# Altium schematic sheet 03 ADA4350.SchDoc
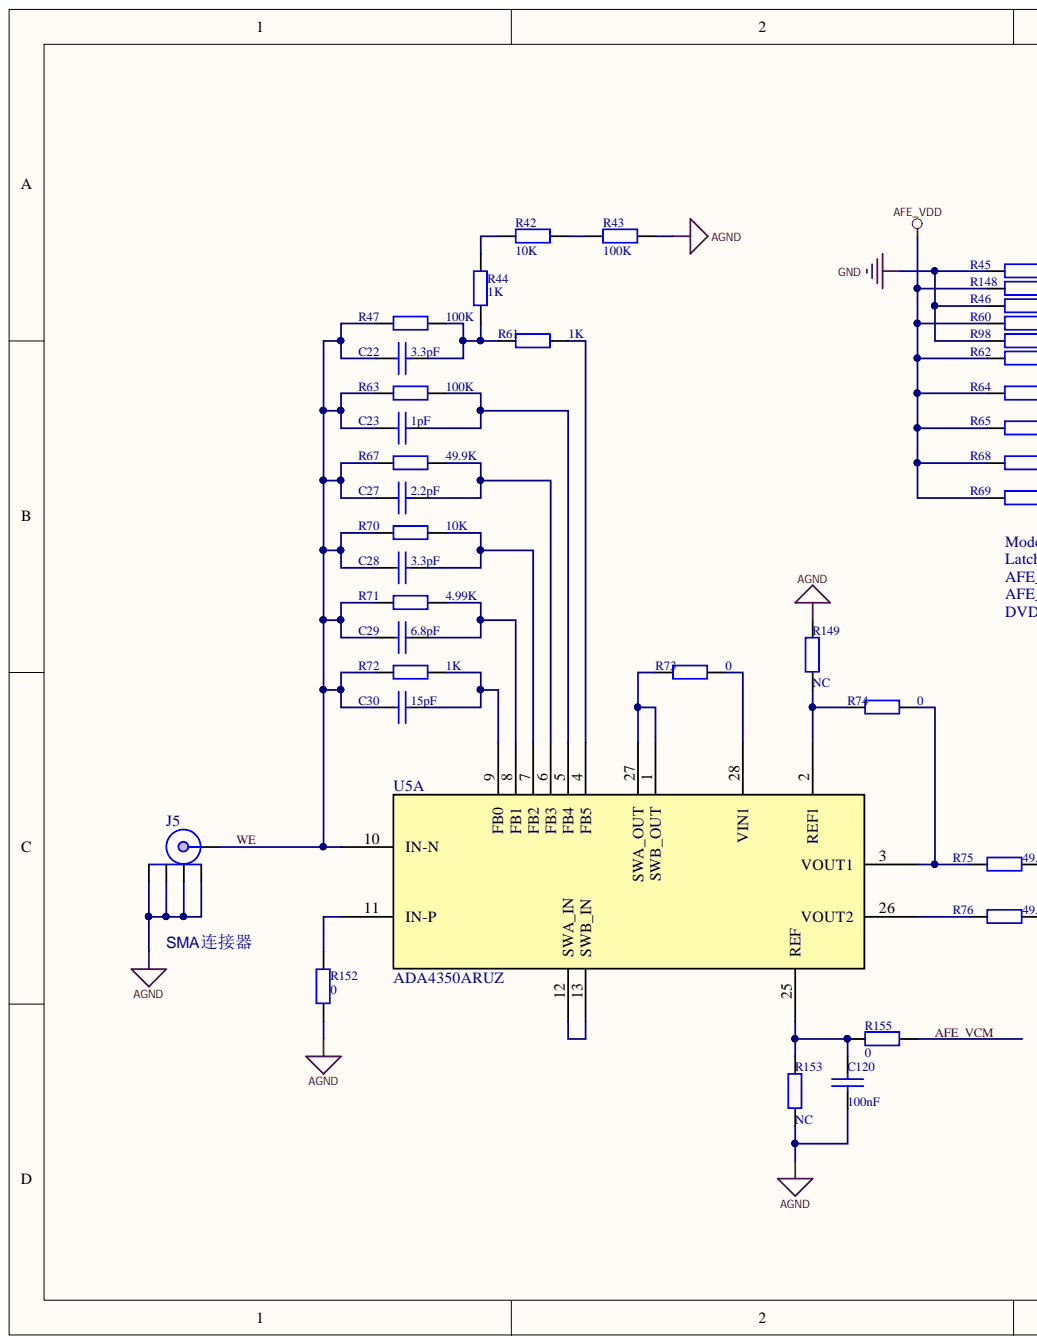
[diagram p0: 03 ADA4350.SchDoc, left]
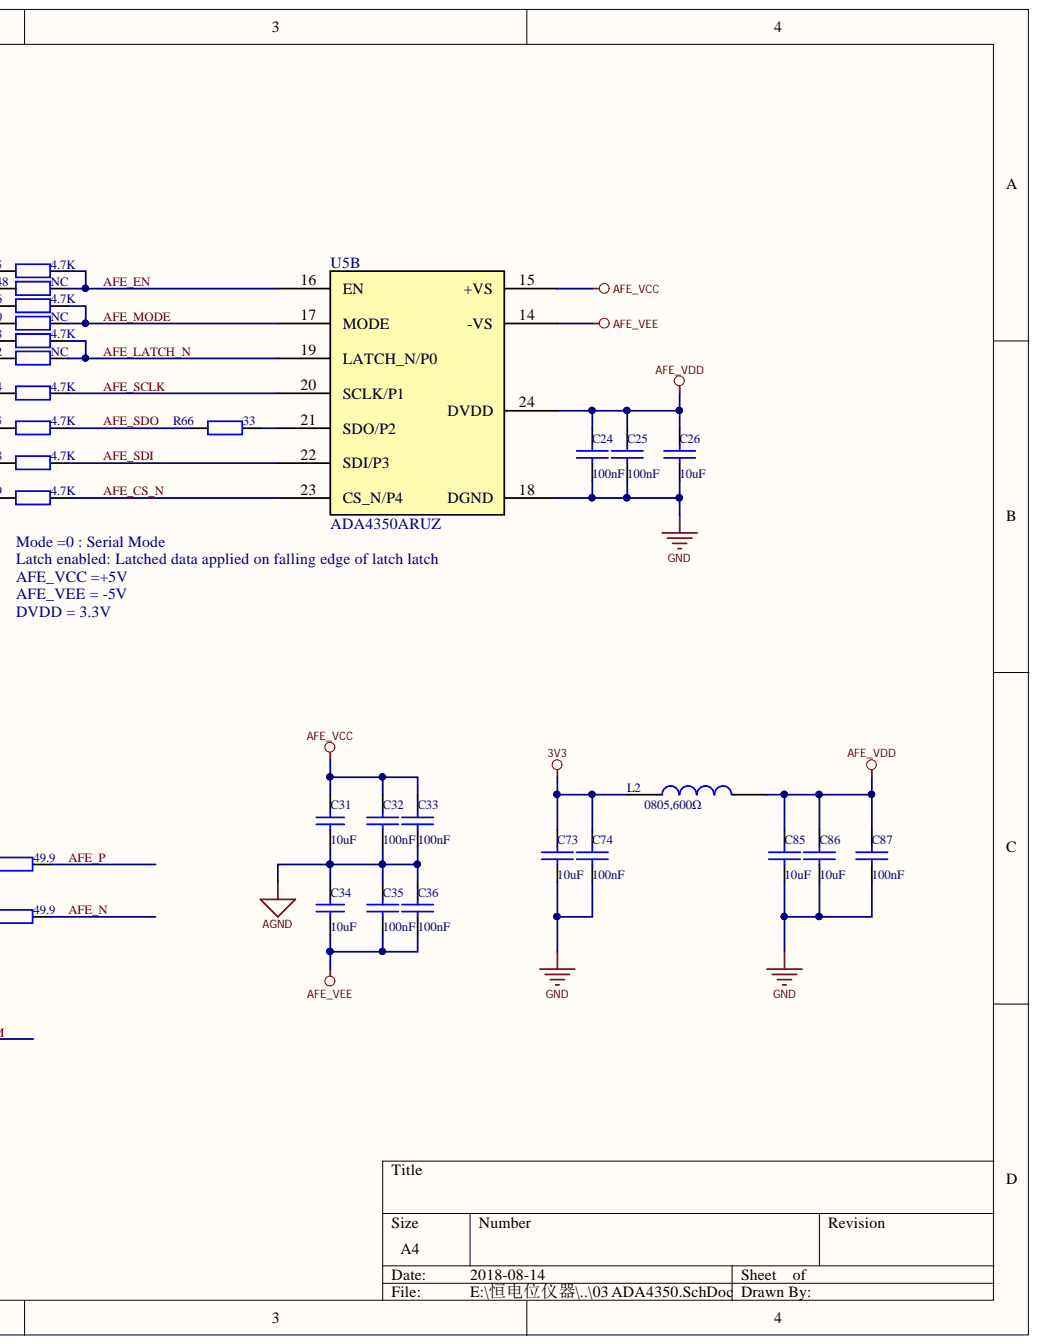
[diagram p1: 03 ADA4350.SchDoc, right]

## Parts
C22  3.3pF  [SC0603]
C23  1pF  [SC0603]
C24  100nF  [SC0402]
C25  100nF  [SC0402]
C26  10uF  [SC0603]
C27  2.2pF  [SC0603]
C28  3.3pF  [SC0603]
C29  6.8pF  [SC0603]
C30  15pF  [SC0603]
C31  10uF  [SC0805]
C32  100nF  [SC0402]
C33  100nF  [SC0402]
C34  10uF  [SC0805]
C35  100nF  [SC0402]
C36  100nF  [SC0402]
C73  10uF  [SC0603]
C74  100nF  [SC0402]
C85  10uF  [SC0603]
C86  10uF  [SC0603]
C87  100nF  [SC0402]
C120  100nF  [SC0402]
J5  SMA连接器  [SMA]
L2  0805,600Ω  [SL0805]
R42  value 10K  [SR0603]  (hidden comment: 10K±1%)
R43  value 100K  [SR0603]  (hidden comment: 100K±1%)
R44  value 1K  [SR0603]  (hidden comment: 1K±1%)
R45  value 4.7K  [SR0402]  (hidden comment: 4.7K±1%)
R46  value 4.7K  [SR0402]  (hidden comment: 4.7K±1%)
R47  value 100K  [SR0603]  (hidden comment: 100K±1%)
R60  value 4.7K  [SR0402]  (hidden comment: 4.7K±1%)
R61  value 1K  [SR0603]  (hidden comment: 1K±1%)
R62  4.7K±1%  [SR0402]  (value not drawn)
R63  value 100K  [SR0603]  (hidden comment: 100K±1%)
R64  value 4.7K  [SR0402]  (hidden comment: 4.7K±1%)
R65  value 4.7K  [SR0402]  (hidden comment: 4.7K±1%)
R66  value 33  [SR0402]  (hidden comment: 33±1%)
R67  value 49.9K  [SR0603]  (hidden comment: 49.9K±1%)
R68  value 4.7K  [SR0402]  (hidden comment: 4.7K±1%)
R69  value 4.7K  [SR0402]  (hidden comment: 4.7K±1%)
R70  value 10K  [SR0603]  (hidden comment: 10K±1%)
R71  value 4.99K  [SR0603]  (hidden comment: 4.99K±1%)
R72  value 1K  [SR0603]  (hidden comment: 1K±1%)
R73  value 0  [SR0603]  (hidden comment: 0±5%)
R74  value 0  [SR0603]  (hidden comment: 0±5%)
R75  value 49.9  [SR0603]  (hidden comment: 49.9±1%)
R76  value 49.9  [SR0603]  (hidden comment: 49.9±1%)
R98  value 4.7K  [SR0402]  (hidden comment: 4.7K±1%)
R148  value 4.7K  [SR0402]  (hidden comment: 4.7K±1%)
R149  1K±1%  [SR0603]  (value not drawn)
R152  value 0  [SR0603]  (hidden comment: 0±5%)
R153  0±5%  [SR0603]  (value not drawn)
R155  value 0  [SR0603]  (hidden comment: 0±5%)
U5  ADA4350ARUZ  [RU_28]  (parts A,B)

## Nets
3V3: C73.2 C74.2 L2.1
AFE_VCC: C31.1 C32.2 C33.2 U5.15(+VS)
AFE_VDD: C24.2 C25.2 C26.2 C85.2 C86.2 C87.2 L2.2 R60.1 R62.1 R64.1 R65.1 R68.1 R69.1 R148.1 U5.24(DVDD)
AFE_VEE: C34.2 C35.1 C36.1 U5.14(-VS)
AGND: C31.2 C32.1 C33.1 C34.1 C35.2 C36.2 C120.1 J5.2 J5.3 J5.4 J5.5 R43.2 R149.2 R152.1 R153.1
GND: C24.1 C25.1 C26.1 C73.1 C74.1 C85.1 C86.1 C87.1 R45.1 R46.1 R98.1 U5.18(DGND)
AFE_CS_N: R69.2 U5.23(CS_N/P4)
AFE_EN: R45.2 R148.2 U5.16(EN)
AFE_LATCH_N: R62.2 R98.2 U5.19(LATCH_N/P0)
AFE_MODE: R46.2 R60.2 U5.17(MODE)
AFE_N: R76.2
AFE_P: R75.2
AFE_SCLK: R64.2 U5.20(SCLK/P1)
AFE_SDI: R68.2 U5.22(SDI/P3)
AFE_SDO: R65.2 R66.1
AFE_VCM: R155.1
WE: C22.2 C23.2 C27.2 C28.2 C29.2 C30.2 J5.1 R47.1 R63.1 R67.1 R70.1 R71.1 R72.1 U5.10(IN-N)
NetC22_1: C22.1 R44.1 R47.2 R61.1
NetC23_1: C23.1 R63.2 U5.5(FB4)
NetC27_1: C27.1 R67.2 U5.6(FB3)
NetC28_1: C28.1 R70.2 U5.7(FB2)
NetC29_1: C29.1 R71.2 U5.8(FB1)
NetC30_1: C30.1 R72.2 U5.9(FB0)
NetC120_2: C120.2 R153.2 R155.2 U5.25(REF)
NetR42_1: R42.1 R44.2
NetR42_2: R42.2 R43.1
NetR61_2: R61.2 U5.4(FB5)
NetR66_2: R66.2 U5.21(SDO/P2)
NetR73_1: R73.1 U5.1(SWB_OUT) U5.27(SWA_OUT)
NetR73_2: R73.2 U5.28(VIN1)
NetR74_1: R74.1 R149.1 U5.2(REF1)
NetR74_2: R74.2 R75.1 U5.3(VOUT1)
NetR76_1: R76.1 U5.26(VOUT2)
NetR152_2: R152.2 U5.11(IN-P)
NetU5_12: U5.12(SWA_IN) U5.13(SWB_IN)

## Library data of the parts
C22 C28 = CAP: Capacitor; Part_Comments: SMD陶瓷电容-50V-3.3pF-+/-5%-NPO-0603; COMPONENT_HIGH_MAX: 0.55MM; DEVICE: CC0603CRNPO9BN3R3; Vendor: Yageo; Voltage: 50V; Component Kind: Standard; Value: 3.3pF
C23 = CAP: Capacitor; Part_Comments: SMD陶瓷电容-50V-1pF-+/-5%-NPO-0603; DEVICE: CC0603CRNPO9BN1R0; Vendor: Yageo; Voltage: 10V; Component Kind: Standard; Value: 1pF
C24 C25 C32 C33 C35 C36 C74 C87 C120 = CAP: Capacitor; Part_Comments: SMD陶瓷电容-10V-100nF-+/-10%-X7R-040; Code: 101024500028; COMPONENT_HIGH_MAX: 0.55MM; DEVICE: CC0402KRX5R6BB104; Vendor: Yageo; Voltage: 10V; Component Kind: Standard; Value: 100nF
C26 C73 C85 C86 = CAP: Capacitor; Part_Comments: SMD陶瓷电容-6.3V-10uF-+/-20%-X5R-0603; Code: 101024500091; COMPONENT_HIGH_MAX: 0.9MM; DEVICE: CC0603MRX5R5BB106; Vendor: Yageo; Voltage: 6.3V; Component Kind: Standard; Value: 10uF
C27 = CAP: Capacitor; Part_Comments: SMD陶瓷电容-50V-2.2pF-+/-5%-NPO-0603; COMPONENT_HIGH_MAX: 0.55MM; DEVICE: CC0603CRNPO9BN2R2; Vendor: Yageo; Voltage: 10V; Component Kind: Standard; Value: 2.2pF
C29 = CAP: Capacitor; Part_Comments: SMD陶瓷电容-50V-6.8pF-+/-5%-NPO-0603; COMPONENT_HIGH_MAX: 0.55MM; DEVICE: CC0603BRNPO9BN6R8; Vendor: Yageo; Voltage: 50V; Component Kind: Standard; Value: 6.8pF
C30 = CAP: Capacitor; Part_Comments: SMD陶瓷电容-50V-15pF-+/-5%-NPO-0603; Code: 101024500021; COMPONENT_HIGH_MAX: 0.55MM; DEVICE: CC0603JRNPO9BN150; Vendor: Yageo; Voltage: 50V; Component Kind: Standard; Value: 15pF
C31 C34 = Cap Semi: Capacitor; Part_Comments: SMD陶瓷电容-25V-10uF-+/-10%-X5R-0805; Code: 101024500042; DEVICE: CC0805KKX5R8BB106; Vendor: Yageo; Voltage: 25V; Component Kind: Standard; Library Name: Miscellaneous Devices.IntLib; Signal Integrity: Cap Semi; Simulation: CAP; Value: 10uF
J5 = CON\SMA-END_1: CONN, BNC, SMA 50-OHM EDGE-LANCH; Part Number: E.F.JOHNSON, 142-0701-851; Component Kind: Standard; Part_Comments: SMA连接器
L2 = INDUCTOR: 贴片磁珠频率-600Ω-±25%-0.25Ω-1.5A-0805; Code: 101037512601; Device: PZ2012U601; Value: PZ2012U601; Vendor: Sunlord; Component Kind: Standard; Part_Comments: 贴片磁珠频率-600Ω-±25%-0.25Ω-1.5A-0805
R42 R70 = Res2: Resistor; Part_Comments: 片式厚膜电阻器-0.1W-10KOHM-+/-1%-0603; DEVICE: RC0603FR-0710KL; Vendor: Yageo; Component Kind: Standard; Library Name: Miscellaneous Devices.IntLib
R43 R47 R63 = Res2: Resistor; Part_Comments: 片式厚膜电阻器-0.1W-100KOHM-+/-1%-0603; DEVICE: RC0603FR-07100KL; Vendor: Yageo; Component Kind: Standard; Library Name: Miscellaneous Devices.IntLib
R44 R61 R72 = Res2: Resistor; Part_Comments: 片式厚膜电阻器-0.1W-1KOHM-+/-1%-0603; Code: 101017310070; DEVICE: RC0603FR-071KL; Vendor: Yageo; Component Kind: Standard; Library Name: Miscellaneous Devices.IntLib
R45 R46 R60 R64 R65 R68 R69 R98 R148 = Res2: Resistor; Part_Comments: 片式厚膜电阻器-0.0625W-4.7KOHM-+/-1%-0402; Code: 101017400060; DEVICE: RC0402FR-074K7L; Vendor: Yageo; Component Kind: Standard; Library Name: Miscellaneous Devices.IntLib; Signal Integrity: Res; Simulation: RESISTOR
  R60 R148: NC: NC
R62 = Res2: Resistor; Part_Comments: 片式厚膜电阻器-0.0625W-4.7KOHM-+/-1%-0402; Code: 101017400060; DEVICE: RC0402FR-074K7L; Value: 4.7K; Vendor: Yageo; Component Kind: Standard; Library Name: Miscellaneous Devices.IntLib; NC: NC; Signal Integrity: Res; Simulation: RESISTOR
R66 = Res2: Resistor; Part_Comments: 片式厚膜电阻器-0.0625W-33OHM-+/-; Code: 101017400043; DEVICE: RC0402FR-0733RL; Component Kind: Standard; Library Name: Miscellaneous Devices.IntLib; Signal Integrity: Res; Simulation: RESISTOR
R67 = Res2: Resistor; Part_Comments: 片式厚膜电阻器-0.1W-49.9KOHM-+/-1%-0603; DEVICE: RC0603FR-0749K9L; Vendor: Yageo; Component Kind: Standard; Library Name: Miscellaneous Devices.IntLib
R71 = Res2: Resistor; Part_Comments: 片式厚膜电阻器-0.1W-4.99KOHM-+/-1%-0603; DEVICE: RC0603FR-074K99L; Vendor: Yageo; Component Kind: Standard; Library Name: Miscellaneous Devices.IntLib
R73 R74 R152 R155 = RES2: Resistor; Part_Comments: 片式厚膜电阻器-0.1W-0OHM-+/-5%-0603; Code: 101017300001; DEVICE: RC0603JR-070RL; Vendor: Yageo; Component Kind: Standard
R75 R76 = Res2: Resistor; Part_Comments: 片式厚膜电阻器-0.1W-49.9OHM-+/-1%-0603; Code: 101017305641; DEVICE: RC0603FR-0749R9L; Vendor: Yageo; Component Kind: Standard; Library Name: Miscellaneous Devices.IntLib
R149 = Res2: Resistor; Part_Comments: 片式厚膜电阻器-0.1W-1KOHM-+/-1%-0603; Code: 101017310070; DEVICE: RC0603FR-071KL; Value: 1K; Vendor: Yageo; Component Kind: Standard; Library Name: Miscellaneous Devices.IntLib; NC: NC
R153 = RES2: Resistor; Part_Comments: 片式厚膜电阻器-0.1W-0OHM-+/-5%-0603; Code: 101017300001; DEVICE: RC0603JR-070RL; Value: 0; Vendor: Yageo; Component Kind: Standard; NC: NC
U5 = ADA4350ARUZ: FET Input Analog Front End  with ADC Driver; Vendor: ADI; Component Kind: Standard; Value: ADA4350ARUZ; Library Name: Potentiostat.SchLib
